# Altium schematic sheet USBanana_v1.1_schematic.SchDoc
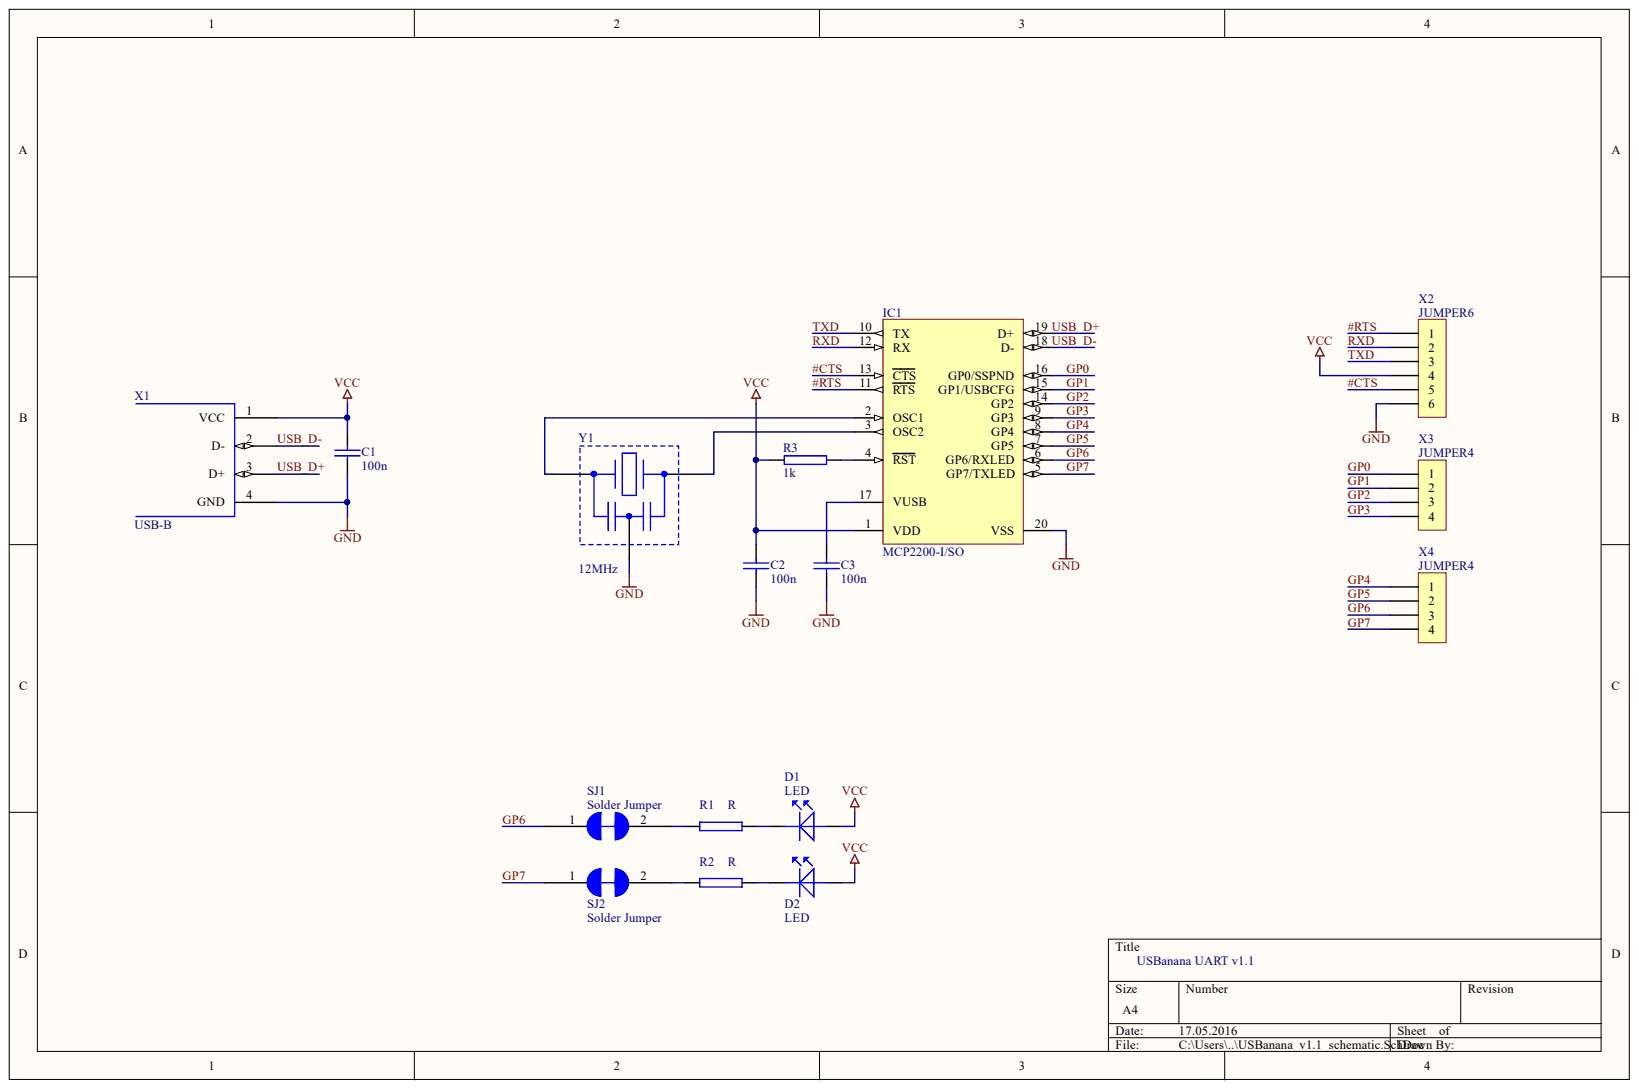
[diagram p0: USBanana_v1.1_schematic.SchDoc]

## Parts
C1  100n  [0805_1]
C2  100n  [0805_1]
C3  100n  [0805_1]
D1  LED  [0805_1]
D2  LED  [0805_1]
IC1  MCP2200-I/SO  [SOIC-SO20_N]
R1  R  [0805_1]
R2  R  [0805_1]
R3  1k  [0805_1]
SJ1  Solder Jumper  [Solder Jumper]
SJ2  Solder Jumper  [Solder Jumper]
X1  USB-B  [USB-B Micro]
X2  JUMPER6  [Jumper6]
X3  JUMPER4  [Jumper4]
X4  JUMPER4  [Jumper4]
Y1  12MHz  [CSTCE12M0]

## Nets
GND: C1.1 C2.1 C3.1 IC1.20(VSS) X1.4(GND) X2.6 Y1.2
VCC: C1.2 C2.2 D1.A D2.A IC1.1(VDD) R3.2 X1.1(VCC) X2.4
#CTS: IC1.13(CTS) X2.5
#RTS: IC1.11(RTS) X2.1
GP0: IC1.16(GP0/SSPND) X3.1
GP1: IC1.15(GP1/USBCFG) X3.2
GP2: IC1.14(GP2) X3.3
GP3: IC1.9(GP3) X3.4
GP4: IC1.8(GP4) X4.1
GP5: IC1.7(GP5) X4.2
GP6: IC1.6(GP6/RXLED) SJ1.1 X4.3
GP7: IC1.5(GP7/TXLED) SJ2.1 X4.4
RXD: IC1.12(RX) X2.2
TXD: IC1.10(TX) X2.3
USB_D+: IC1.19(D+) X1.3(D+)
USB_D-: IC1.18(D-) X1.2(D-)
NetC3_2: C3.2 IC1.17(VUSB)
NetD1_K: D1.K R1.2
NetD2_K: D2.K R2.2
NetIC1_2: IC1.2(OSC1) Y1.1
NetIC1_3: IC1.3(OSC2) Y1.3
NetIC1_4: IC1.4(RST) R3.1
NetR1_1: R1.1 SJ1.2
NetR2_1: R2.1 SJ2.2

## Library data of the parts
C1-C3 = KO: Kondensator; Component Kind: Standard; Library Name: DANG_Diskret.Lib
IC1 = MCP2200-I/SO: USB 2.0 to UART Protocol Converter with GPIO, 20-Pin SOIC, Industrial Temperature; Manufacturer: Microchip; Code_IPC: SOIC127P1030-20; Code_JEDEC: MS-013-AC; Component Kind: Standard; ComponentLink1Description: Manufacturer URL; ComponentLink1URL: http://www.microchip.com/; ComponentLink2Description: Datasheet; ComponentLink2URL: http://ww1.microchip.com/downloads/en/DeviceDoc/22228B.pdf; ComponentLink3Description: Package Specification; ComponentLink3URL: http://www.microchip.com/stellent/groups/techpub_sg/documents/packagingspec/en012702.pdf; DatasheetVersion: revB, Mar-2011; Library Name: Microchip Interface.IntLib; Mounting Technology: Surface Mount; PackageDescription: 20-Lead Plastic Small Outline (SO) - Wide 7.50mm Body [SOIC]; PackageReference: SOIC-SO20; PackageVersion: revBB, Aug-2009; PartNumber: MCP2200-I/SO; Supplier 1: Digi-Key; Supplier 2: Farnell; Supplier 3: Newark; Supplier 4: Mouser; Supplier Part Number 1: MCP2200-I/SO-ND; Supplier Part Number 2: 1781148; Supplier Part Number 3: 52R9947; Supplier Part Number 4: 579-MCP2200-I/SO
R1-R3 = R: Widerstand; Component Kind: Standard; Library Name: DANG_Diskret.Lib
X1 = USB-B: USB B-Type Connector; Component Kind: Standard; Library Name: happ_uC.LIB
X2 = JUMPER6: Jumper; Component Kind: Standard; Library Name: DANG_Stecker.Lib
X3 X4 = JUMPER4: Jumper; Component Kind: Standard; Library Name: DANG_Stecker.Lib
Y1 = Resonator: Ceramic Crystal Resonator; Component Kind: Standard; Library Name: happ_uC.LIB
D1 D2 = LED: Component Kind: Standard; Description: LED; Library Name: DANG_Opto.Lib
SJ1 SJ2 = Solder Jumper: Component Kind: Standard; Library Name: happ_uC.LIB
